# Altium schematic sheet Supply_0.SchDoc
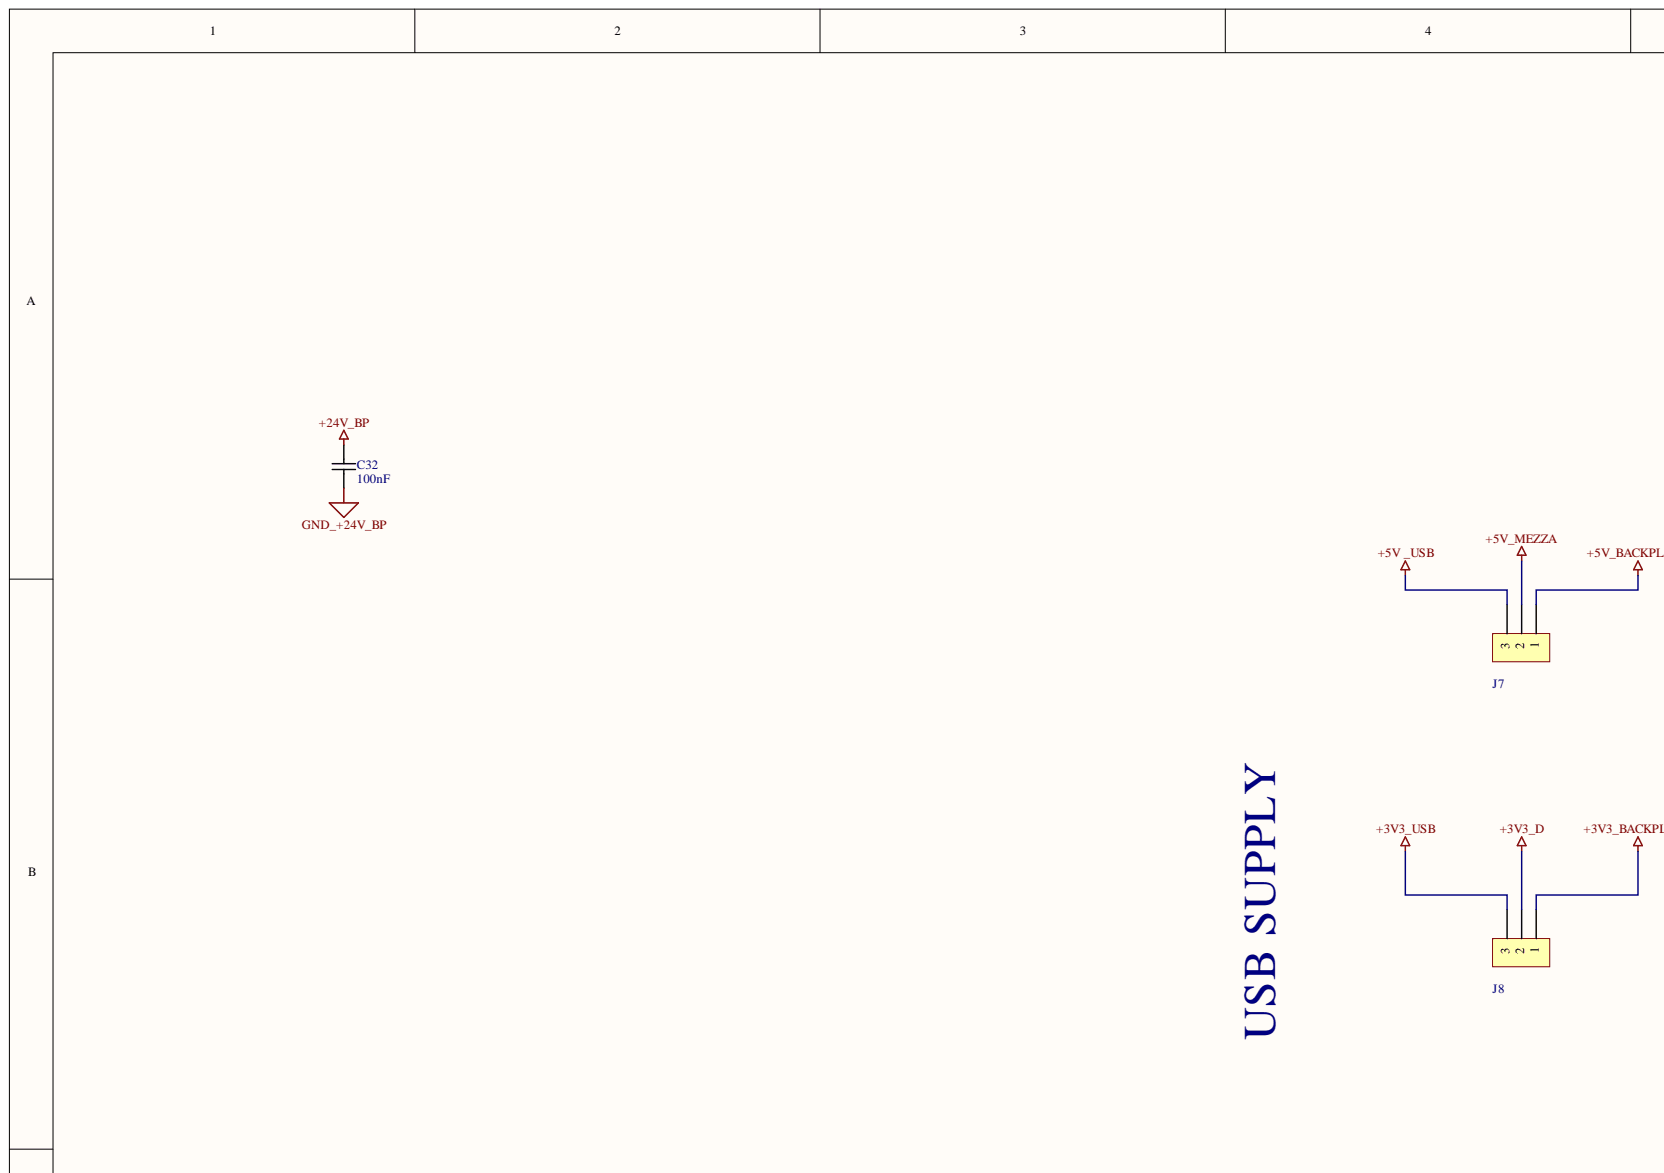
[diagram p0: Supply_0.SchDoc, top left]
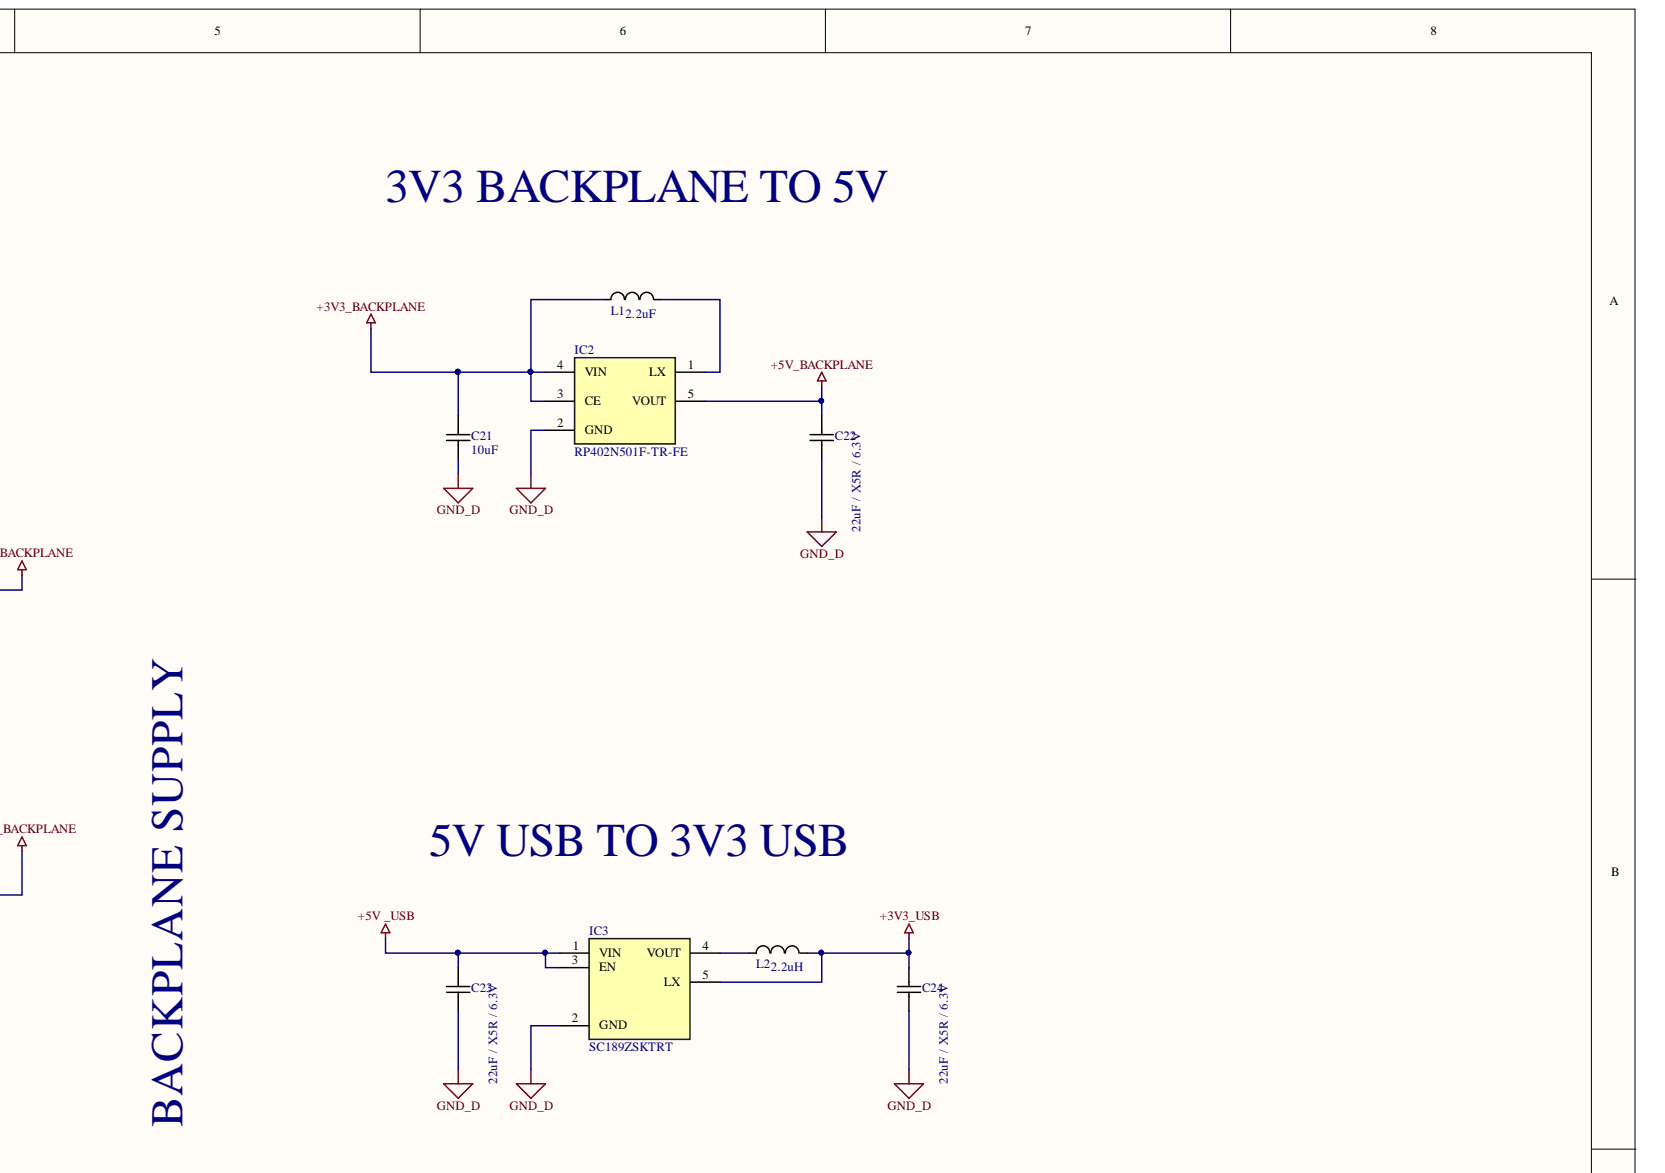
[diagram p1: Supply_0.SchDoc, top right]
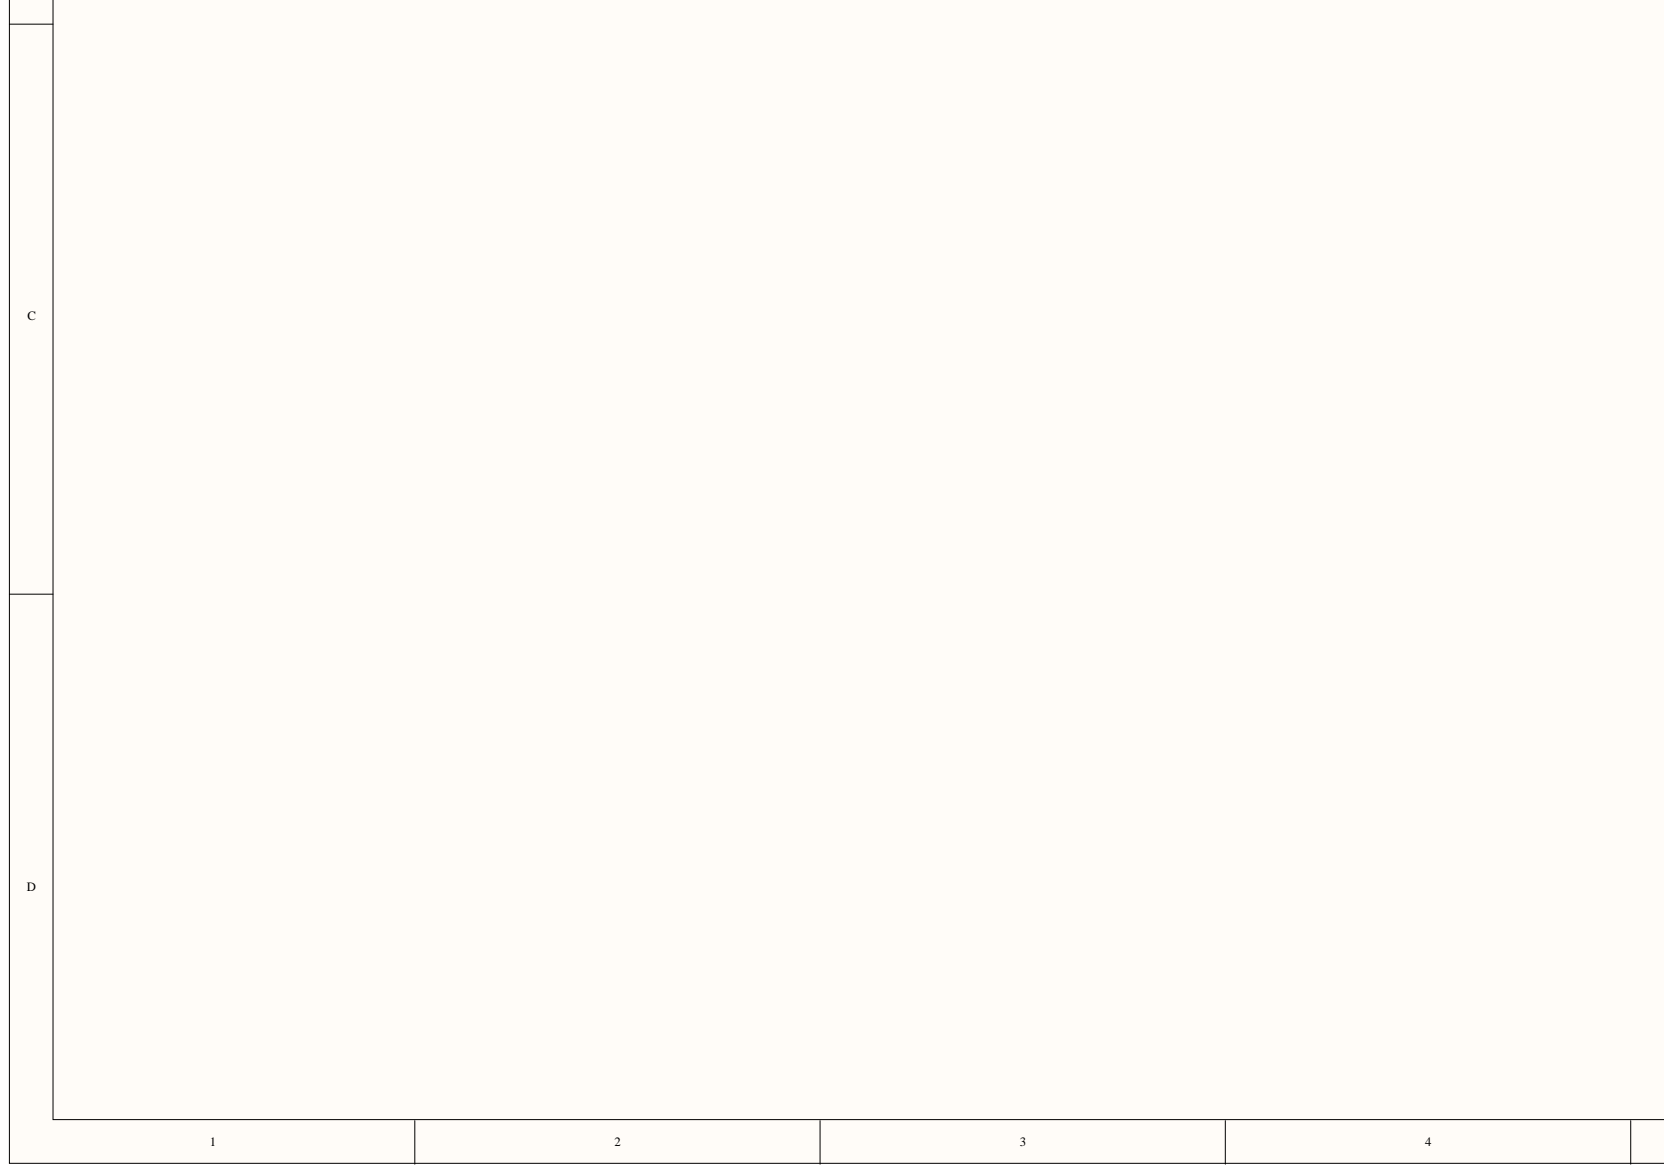
[diagram p2: Supply_0.SchDoc, bottom left]
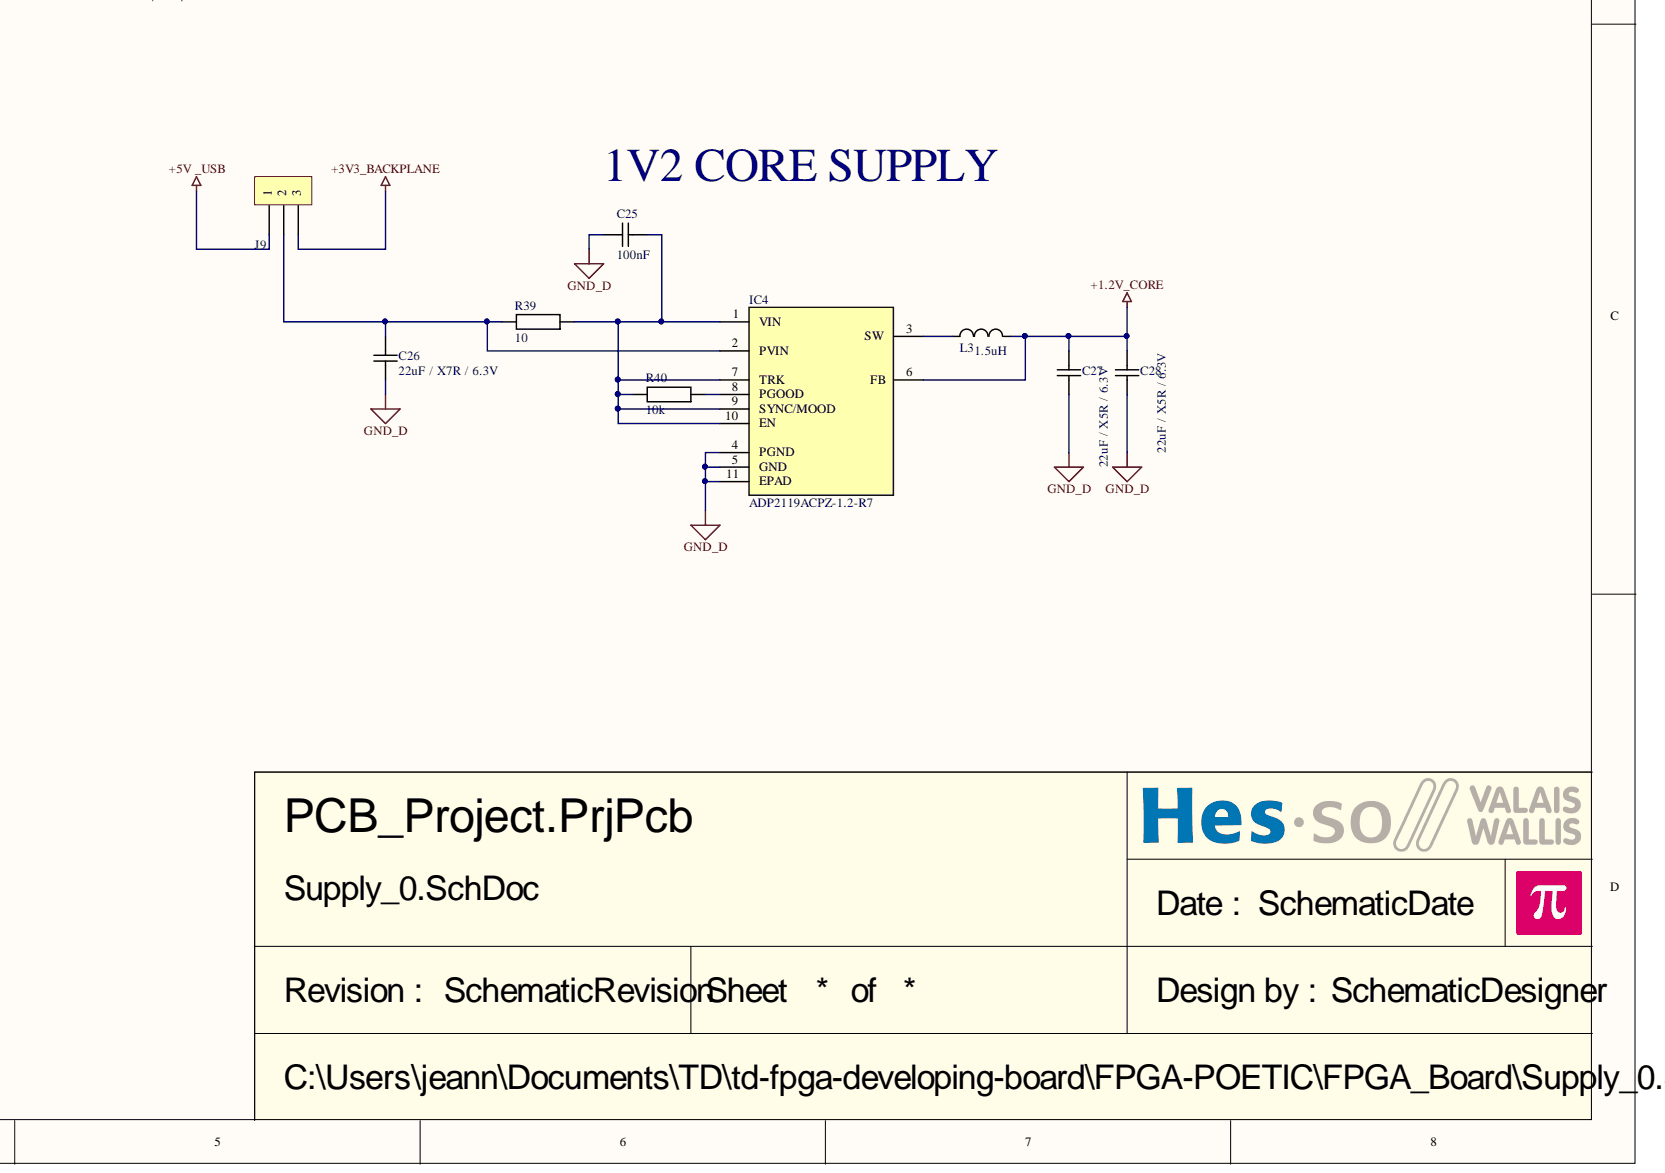
[diagram p3: Supply_0.SchDoc, bottom right]

## Parts
C21  10uF  [CAPACITOR 2012 REFLOW]
C22  22uF / X5R / 6.3V  [CAPACITOR 2012 REFLOW]
C23  22uF / X5R / 6.3V  [CAPACITOR 2012 REFLOW]
C24  22uF / X5R / 6.3V  [CAPACITOR 2012 REFLOW]
C25  100nF  [CAPACITOR 1608 REFLOW]
C26  22uF / X7R / 6.3V  [CAPACITOR 2012 REFLOW]
C27  22uF / X5R / 6.3V  [CAPACITOR 2012 REFLOW]
C28  22uF / X5R / 6.3V  [CAPACITOR 2012 REFLOW]
C32  100nF  [CAPACITOR 1608 REFLOW]
IC2  RP402N501F-TR-FE  [SOT95P280X130-5N]
IC3  SC189ZSKTRT  [SOT95P280X145-5N]
IC4  ADP2119ACPZ-1.2-R7  [SON50P300X300X85-11N]
J7  (no value)  [PinHeader1x3 P2.54]
J8  (no value)  [PinHeader1x3 P2.54]
J9  (no value)  [PinHeader1x3 P2.54]
L1  2.2uF  [TDK VLCF5020-1]
L2  2.2uH  [TDK VLCF5020-1]
L3  1.5uH  [TDK VLCF5020-1]
R39  10  [RESISTOR 2012 REFLOW]
R40  10k  [RESISTOR 2012 REFLOW]

## Nets
+1.2V_CORE: C27.2 C28.2 IC4.6(FB) L3.2
+3V3_BACKPLANE: C21.2 IC2.3(CE) IC2.4(VIN) J8.1 J9.3 L1.1
+3V3_D: J8.2
+3V3_USB: C24.2 IC3.5(LX) J8.3 L2.2
+5V _USB: C23.2 IC3.1(VIN) IC3.3(EN) J7.3 J9.1
+5V_BACKPLANE: C22.2 IC2.5(VOUT) J7.1
+5V_MEZZA: J7.2
+24V_BP: C32.2
GND_+24V_BP: C32.1
GND_D: C21.1 C22.1 C23.1 C24.1 C25.2 C26.1 C27.1 C28.1 IC2.2(GND) IC3.2(GND) IC4.4(PGND) IC4.5(GND) IC4.11(EPAD)
NetC25_1: C25.1 IC4.1(VIN) IC4.7(TRK) IC4.9(SYNC/MOOD) IC4.10(EN) R39.1 R40.2
NetC26_2: C26.2 IC4.2(PVIN) J9.2 R39.2
NetIC2_1: IC2.1(LX) L1.2
NetIC3_4: IC3.4(VOUT) L2.1
NetIC4_3: IC4.3(SW) L3.1
NetIC4_8: IC4.8(PGOOD) R40.1
N00596:

## Library data of the parts
C21-C28 = Capacitor: Component Kind: Standard; Description: Capacitor; Library Name: Capacitor.IntLib
  C21: Value: 10uF
  C22-C24 C27 C28: Value: 22uF / X5R / 6.3V
  C25: Value: 100nF
  C26: Value: 22uF / X7R / 6.3V
C32 = Capacitor: Capacitor X7R 10% 50V 1608(0603); Component Kind: Standard; Library Name: Capacitor.IntLib; Value: 100nF
IC2 = RP402N501F-TR-FE: Integrated Circuit; Manufacturer_Name: Ricoh; Arrow Part Number: RP402N501F-TR-FE; Arrow Price/Stock: https://www.arrow.com/en/products/rp402n501f-tr-fe/ricoh-electronic-devices-company; Component Kind: Standard; Datasheet Link: https://www.arrow.com/en/products/rp402n501f-tr-fe/ricoh-electronic-devices-company; Height: 1.3mm; Library Name: SamacSys.SchLib; Manufacturer_Part_Number: RP402N501F-TR-FE; Mouser Part Number: 848-RP402N501F-TR-FE; Mouser Price/Stock: https://www.mouser.co.uk/ProductDetail/Ricoh-Electronic-Devices-Company/RP402N501F-TR-FE?qs=ilL34mSzaLj3p4B9FaWLxQ%3D%3D
IC3 = SC189ZSKTRT: Integrated Circuit; Manufacturer_Name: SEMTECH; Arrow Part Number: SC189ZSKTRT; Arrow Price/Stock: https://www.arrow.com/en/products/sc189zsktrt/semtech; Component Kind: Standard; Datasheet Link: http://www.semtech.com/images/datasheet/sc189.pdf; Height: 1.45mm; Library Name: SamacSys.SchLib; Manufacturer_Part_Number: SC189ZSKTRT; Mouser Part Number: 947-SC189ZSKTRT; Mouser Price/Stock: https://www.mouser.co.uk/ProductDetail/Semtech/SC189ZSKTRT/?qs=rBWM4%252BvDhIck0D%2FgoUNMIQ%3D%3D
IC4 = ADP2119ACPZ-1.2-R7: Integrated Circuit; Manufacturer_Name: Analog Devices; Arrow Part Number: ADP2119ACPZ-1.2-R7; Arrow Price/Stock: https://www.arrow.com/en/products/adp2119acpz-1.2-r7/analog-devices; Component Kind: Standard; Library Name: SamacSys.SchLib; Manufacturer_Part_Number: ADP2119ACPZ-1.2-R7; Mouser Part Number: 584-ADP2119ACPZ1.2R7; Mouser Price/Stock: https://www.mouser.co.uk/ProductDetail/Analog-Devices/ADP2119ACPZ-12-R7?qs=WIvQP4zGanjkVnaXN%2F8Aww%3D%3D
J7-J9 = PinHeader1x3: Plug connector; Component Kind: Standard; Library Name: PinHeader.IntLib
L1 = Inductor: Name: NRS5020T2R2NMGJ; Component Kind: Standard; Description: Inductor; Library Name: Inductor.IntLib; Value: 2.2uF
L2 = Inductor: Name: 74437334022; Component Kind: Standard; Description: Inductor; Library Name: Inductor.IntLib; Value: 2.2uH
L3 = Inductor: Name: 74437334015; Component Kind: Standard; Description: Inductor; Library Name: Inductor.IntLib; Value: 1.5uH
R39 R40 = Resistor: Resistor 1% 50V 125mW 2012(0805); Component Kind: Standard; Library Name: Resistor.IntLib
  R39: Value: 10
  R40: Value: 10k
